# Altium schematic sheet Sheet3.SchDoc(Sheet3)
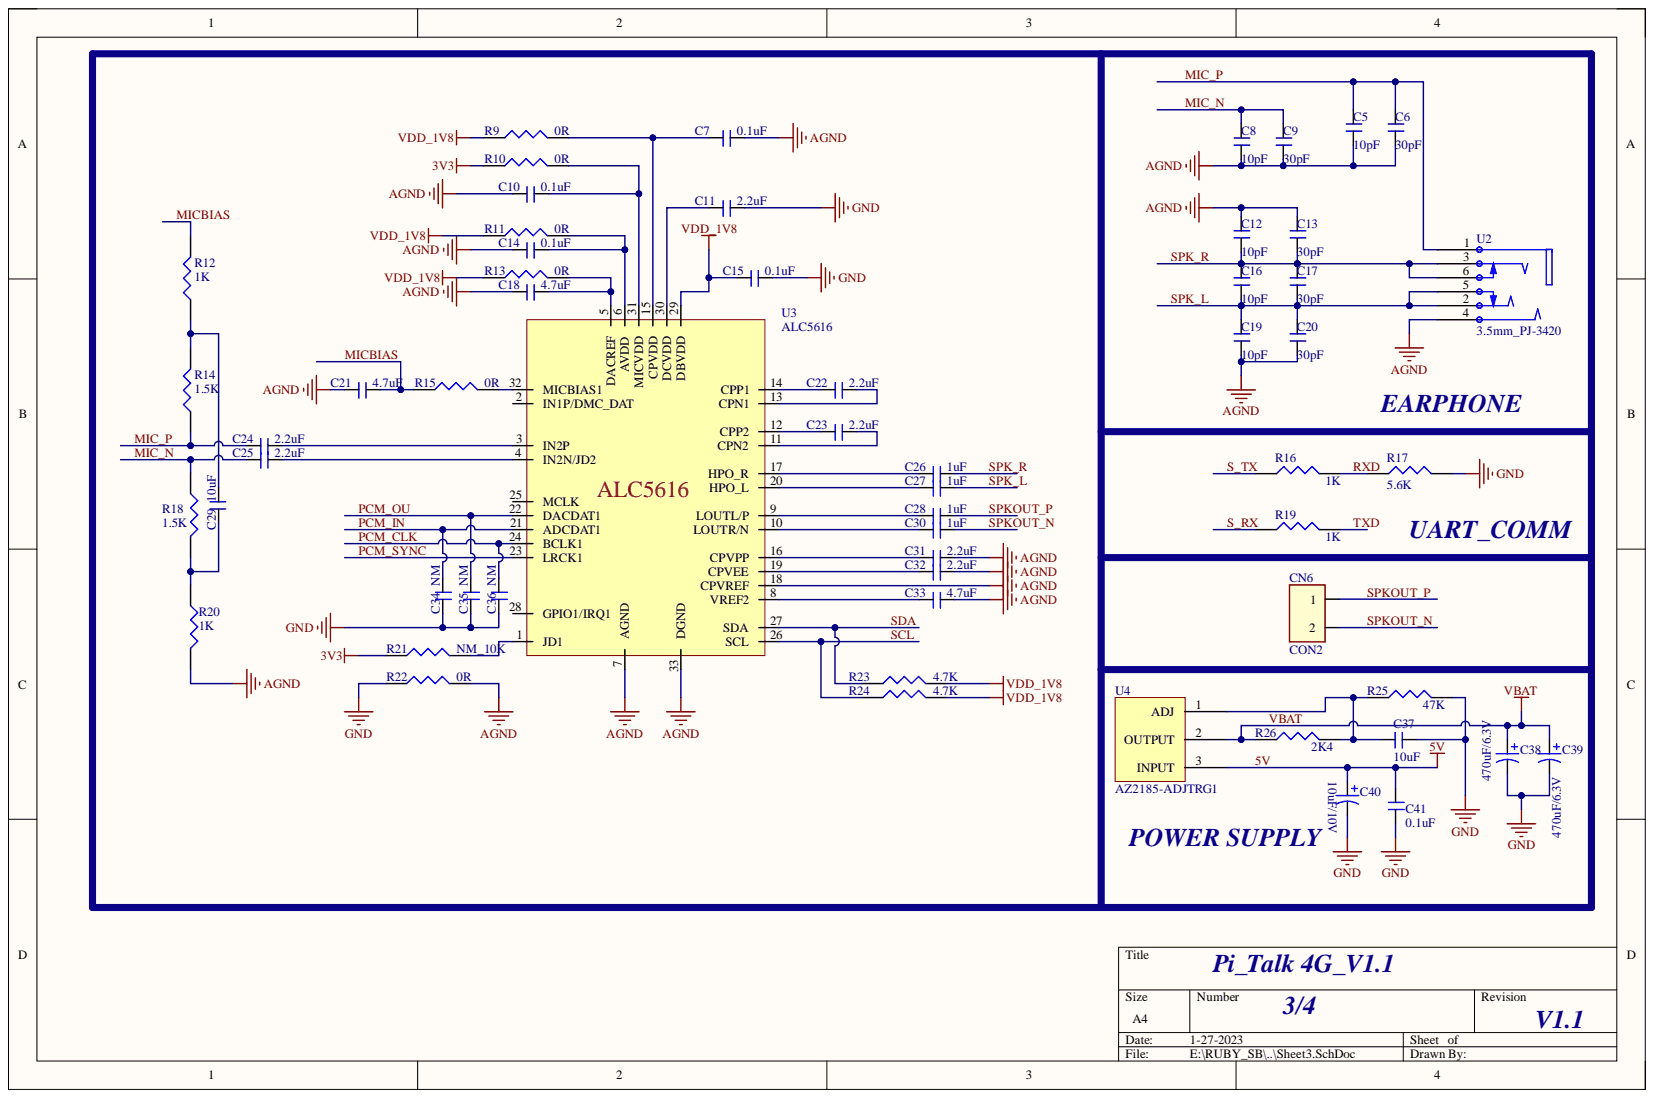
[diagram p0: Sheet3.SchDoc]

## Parts
C5  10pF  [CAP_0603_NONPOLAR]
C6  30pF  [CAP_0603_NONPOLAR]
C7  0.1uF  [CAP_0603_NONPOLAR]
C8  10pF  [CAP_0603_NONPOLAR]
C9  30pF  [CAP_0603_NONPOLAR]
C10  0.1uF  [CAP_0603_NONPOLAR]
C11  2.2uF  [CAP_0603_NONPOLAR]
C12  10pF  [CAP_0603_NONPOLAR]
C13  30pF  [CAP_0603_NONPOLAR]
C14  0.1uF  [CAP_0603_NONPOLAR]
C15  0.1uF  [CAP_0603_NONPOLAR]
C16  10pF  [CAP_0603_NONPOLAR]
C17  30pF  [CAP_0603_NONPOLAR]
C18  4.7uF  [CAP_0603_NONPOLAR]
C19  10pF  [CAP_0603_NONPOLAR]
C20  30pF  [CAP_0603_NONPOLAR]
C21  4.7uF  [CAP_0603_NONPOLAR]
C22  2.2uF  [CAP_0603_NONPOLAR]
C23  2.2uF  [CAP_0603_NONPOLAR]
C24  2.2uF  [CAP_0603_NONPOLAR]
C25  2.2uF  [CAP_0603_NONPOLAR]
C26  1uF  [CAP_0603_NONPOLAR]
C27  1uF  [CAP_0603_NONPOLAR]
C28  1uF  [CAP_0603_NONPOLAR]
C29  10uF  [CAP_0603_NONPOLAR]
C30  1uF  [CAP_0603_NONPOLAR]
C31  2.2uF  [CAP_0603_NONPOLAR]
C32  2.2uF  [CAP_0603_NONPOLAR]
C33  4.7uF  [CAP_0603_NONPOLAR]
C34  NM  [CAP_0603_NONPOLAR]
C35  NM  [CAP_0603_NONPOLAR]
C36  NM  [CAP_0603_NONPOLAR]
C37  10uF  [CAP_0603_NONPOLAR]
C38  470uF/6.3V  [CASE-D_7343]
C39  470uF/6.3V  [CASE-D_7343]
C40  10uF/10V  [CAP_TANTALUM CASE-A_3216]
C41  0.1uF  [CAP_0603_NONPOLAR]
CN6  CON2  [CON2_BJ]
R9  0R  [0603]
R10  0R  [0603]
R11  0R  [0603]
R12  1K  [0603]
R13  0R  [0603]
R14  1.5K  [0603]
R15  0R  [0603]
R16  1K  [0603]
R17  5.6K  [0603]
R18  1.5K  [0603]
R19  1K  [0603]
R20  1K  [0603]
R21  NM_10K  [0603]
R22  0R  [0603]
R23  4.7K  [0603]
R24  4.7K  [0603]
R25  47K  [0603]
R26  2K4  [0603]
U2  3.5mm_PJ-3420  [PJ-3420 X-SMT 3.5 PHONE JACK]
U3  ALC5616  [QFN32 4X4X0.55MM]
U4  AZ2185-ADJTRG1  [TO-252-3]

## Nets
3V3: R10.1 R21.1
AGND: C5.1 C6.1 C7.2 C8.1 C9.1 C10.1 C12.2 C13.2 C14.1 C18.1 C19.1 C20.1 C21.1 C31.2 C32.2 C33.2 R20.2 R22.2 U2.4 U3.7(AGND) U3.18(CPVREF) U3.33(DGND)
GND: C11.2 C15.2 C34.1 C35.1 C36.1 C37.2 C38.K C39.K C40.K C41.1 R17.2 R22.1 R25.2
VDD_1V8: C15.1 R9.1 R11.1 R13.1 R23.2 R24.2 U3.29(DBVDD)
5V: C40.A C41.2 U4.3
MIC_N: C8.2 C9.2 C25.1 R18.1
MIC_P: C5.2 C6.2 C24.1 R14.1 U2.1
MICBIAS: C21.2 R12.2 R15.1
PCM_CLK: C36.2 U3.24(BCLK1)
PCM_IN: C34.2 U3.21(ADCDAT1)
PCM_OU: C35.2 U3.22(DACDAT1)
PCM_SYNC: U3.23(LRCK1)
RXD: R16.2 R17.1
S_RX: R19.1
S_TX: R16.1
SCL: R24.1 U3.26(SCL)
SDA: R23.1 U3.27(SDA)
SPK_L: C16.1 C17.1 C19.2 C20.2 C27.2 U2.2 U2.5
SPK_R: C12.1 C13.1 C16.2 C17.2 C26.2 U2.3 U2.6
SPKOUT_N: C30.2 CN6.2
SPKOUT_P: C28.2 CN6.1
TXD: R19.2
VBAT: C38.A C39.A R26.1 U4.2
NetC7_1: C7.1 R9.2 U3.15(CPVDD)
NetC10_2: C10.2 R10.2 U3.31(MICVDD)
NetC11_1: C11.1 U3.30(DCVDD)
NetC14_2: C14.2 R11.2 U3.6(AVDD)
NetC18_2: C18.2 R13.2 U3.5(DACREF)
NetC22_1: C22.1 U3.14(CPP1)
NetC22_2: C22.2 U3.13(CPN1)
NetC23_1: C23.1 U3.12(CPP2)
NetC23_2: C23.2 U3.11(CPN2)
NetC24_2: C24.2 U3.3(IN2P)
NetC25_2: C25.2 U3.4(IN2N/JD2)
NetC26_1: C26.1 U3.17(HPO_R)
NetC27_1: C27.1 U3.20(HPO_L)
NetC28_1: C28.1 U3.9(LOUTL/P)
NetC29_1: C29.1 R12.1 R14.2
NetC29_2: C29.2 R18.2 R20.1
NetC30_1: C30.1 U3.10(LOUTR/N)
NetC31_1: C31.1 U3.16(CPVPP)
NetC32_1: C32.1 U3.19(CPVEE)
NetC33_1: C33.1 U3.8(VREF2)
NetC37_1: C37.1 R25.1 R26.2 U4.1
NetR15_2: R15.2 U3.32(MICBIAS1)
NetR21_2: R21.2 U3.1(JD1)

Not connected: U3 2(IN1P/DMC_DAT) 25(MCLK) 28(GPIO1/IRQ1)

## Library data of the parts
C5-C37 C41 = CAP_NONPOLAR: Component Kind: Standard; Library Name: dxp_pasl_lib.IntLib
C38-C40 = CAP_ELEC: Component Kind: Standard; Library Name: dxp_pasl_lib.IntLib
R9-R26 = RES: Component Kind: Standard; Library Name: dxp_pasl_lib.IntLib
U2 = Headphone Holder SMD: Component Kind: Standard; Library Name: dxp_pasl_lib.IntLib
U3 = ALC5616: Ultra-Low Power Audio CODEC QFN-32 ICs ROHS #C472693; CATEGORY: AUDIO IC; Component Kind: Standard; Library Name: dxp_pasl_lib.IntLib
U4 = AZ2185-ADJTRG1: 3A LOW DROPOUT LINEAR REGULATOR TO-252-3 PACKAGE; Component Kind: Standard; Library Name: dxp_pasl_lib.IntLib
CN6 = CON2: Component Kind: Standard; Library Name: dxp_pasl_lib.IntLib
